# Altium schematic sheet SmartToy Main Board REV02 Schematic.SchDoc("SmartToy Main Board REV02 Schematic") (continued)
## Library data of the parts (continued)
R30 R31 = RES_SMD: RES SMD 100 OHM 5% 1/16W 0402; Manufacturer: Yageo; Manufacturer Part Number: RC0402FR-07100RL; Power Rating: 62.5mW; Resistance: 100R; Temperature Coefficient: 100ppm/°C; Voltage Rating: 50V; Case Code (Metric): 1005; Case/Package: 0402; Component Kind: Standard; ComponentLink1Description: https://datasheet.ciiva.com/7850/1018594-7850134.pdf?src-supplier=Element14; ComponentLink1URL: https://datasheet.ciiva.com/7850/1018594-7850134.pdf?src-supplier=Element14; ComponentLink2Description: https://datasheet.ciiva.com/7850/1018594-7850135.pdf?src-supplier=Element14; ComponentLink2URL: https://datasheet.ciiva.com/7850/1018594-7850135.pdf?src-supplier=Element14; ComponentLink3Description: https://datasheet.ciiva.com/2452/pyu-r-int-thick-7-2452617.pdf?src-supplier=Digi-Key; ComponentLink3URL: https://datasheet.ciiva.com/2452/pyu-r-int-thick-7-2452617.pdf?src-supplier=Digi-Key; ComponentLink4Description: https://datasheet.ciiva.com/2452/pyu-r-int-thick-7-2452618.pdf?src-supplier=Digi-Key; ComponentLink4URL: https://datasheet.ciiva.com/2452/pyu-r-int-thick-7-2452618.pdf?src-supplier=Digi-Key; ComponentLink5Description: https://datasheet.ciiva.com/2452/pyu-r-int-thick-7-2452619.pdf?src-supplier=Digi-Key; ComponentLink5URL: https://datasheet.ciiva.com/2452/pyu-r-int-thick-7-2452619.pdf?src-supplier=Digi-Key; ComponentLink6Description: https://datasheet.ciiva.com/16740/pyu-rc0402-51-rohs-l-6-r-16740924.pdf?src-supplier=Future+Electronics; ComponentLink6URL: https://datasheet.ciiva.com/16740/pyu-rc0402-51-rohs-l-6-r-16740924.pdf?src-supplier=Future+Electronics; ComponentLink7Description: https://datasheet.ciiva.com/12577/pyu-rc0402-51-rohs-l-6-r-12577725.pdf?src-supplier=Mouser; ComponentLink7URL: https://datasheet.ciiva.com/12577/pyu-rc0402-51-rohs-l-6-r-12577725.pdf?src-supplier=Mouser; ComponentLink8Description: https://datasheet.ciiva.com/46394/1018594-46394943.pdf?src-supplier=Element14; ComponentLink8URL: https://datasheet.ciiva.com/46394/1018594-46394943.pdf?src-supplier=Element14; ComponentLink9Description: https://datasheet.ciiva.com/7849/1784430-7849810.pdf?src-supplier=Element14; ComponentLink9URL: https://datasheet.ciiva.com/7849/1784430-7849810.pdf?src-supplier=Element14; ComponentLink10Description: https://datasheet.ciiva.com/11808/0900766b80c66297-11808765.pdf?src-supplier=RSComponents; ComponentLink10URL: https://datasheet.ciiva.com/11808/0900766b80c66297-11808765.pdf?src-supplier=RSComponents; ComponentLink11Description: https://datasheet.ciiva.com/12667/pyu-rc0402-51-rohs-l-6-r-12667957.pdf?src-supplier=Mouser; ComponentLink11URL: https://datasheet.ciiva.com/12667/pyu-rc0402-51-rohs-l-6-r-12667957.pdf?src-supplier=Mouser; ComponentLink12Description: https://datasheet.ciiva.com/16740/pyu-rc0402-51-rohs-l-6-r-16740566.pdf?src-supplier=Future+Electronics; ComponentLink12URL: https://datasheet.ciiva.com/16740/pyu-rc0402-51-rohs-l-6-r-16740566.pdf?src-supplier=Future+Electronics; ComponentLink13Description: https://datasheet.ciiva.com/15189/getdatasheetpartid-329466-15189335.pdf?src-supplier=Verical; ComponentLink13URL: https://datasheet.ciiva.com/15189/getdatasheetpartid-329466-15189335.pdf?src-supplier=Verical; ComponentLink14Description: https://datasheet.ciiva.com/22747/1018594-22747511.pdf?src-supplier=Element14; ComponentLink14URL: https://datasheet.ciiva.com/22747/1018594-22747511.pdf?src-supplier=Element14; ComponentLink15Description: https://datasheet.ciiva.com/28367/1018594-28367226.pdf?src-supplier=Element14; ComponentLink15URL: https://datasheet.ciiva.com/28367/1018594-28367226.pdf?src-supplier=Element14; Depth: 0.5mm; Height: 0.32mm; Lead Free: Lead Free; Length: 1mm; Library Name: CommonLib.SchLib; Max Operating Temperature: 155°C; Military Standard: Not; Min Operating Temperature: -55°C; Number of Pins: 2; Packaging: Tape and Reel; Pricing 1: 1 = 0.10000, 10 = 0.01400, 100 = 0.00580, 1000 = 0.00260, 2500 = 0.00226, 5000 = 0.00186 (USD); REACH SVHC: No SVHC; Resistor Type: Thick Film; RoHS: Yes; Stock 1: 18149776; Supplier 1: Digi-Key; Supplier Part Number 1: 311-100LRCT-ND; Termination: SMD/SMT; Voltage Rating (DC): 50V; Width: 0.5mm
R46 = RES_SMD: YAGEO (PHYCOMP) - RC0603FR-07330RL - RES, THICK FILM, 330R, 1%, 0.1W, 0603; Max Power Dissipation: 100mW; Mouting Technology: SMT; Temperature Coefficient: 100ppm/°C; Voltage Rating: 50V; Case Code (Metric): 1608; Case/Package: 0603; Category: Chip SMD Resistors; Component Kind: Standard; ComponentLink1Description: https://datasheet.ciiva.com/11819/0900766b80f96399-11819327.pdf?src-supplier=RSComponents; ComponentLink1URL: https://datasheet.ciiva.com/11819/0900766b80f96399-11819327.pdf?src-supplier=RSComponents; ComponentLink2Description: https://datasheet.ciiva.com/2454/pyu-r-int-thick-7-2454864.pdf?src-supplier=Digi-Key; ComponentLink2URL: https://datasheet.ciiva.com/2454/pyu-r-int-thick-7-2454864.pdf?src-supplier=Digi-Key; ComponentLink3Description: https://datasheet.ciiva.com/2454/pyu-r-int-thick-7-2454865.pdf?src-supplier=Digi-Key; ComponentLink3URL: https://datasheet.ciiva.com/2454/pyu-r-int-thick-7-2454865.pdf?src-supplier=Digi-Key; ComponentLink4Description: https://datasheet.ciiva.com/2454/pyu-r-int-thick-7-2454866.pdf?src-supplier=Digi-Key; ComponentLink4URL: https://datasheet.ciiva.com/2454/pyu-r-int-thick-7-2454866.pdf?src-supplier=Digi-Key; ComponentLink5Description: https://datasheet.ciiva.com/12555/rc0603-272842-12555334.pdf?src-supplier=Mouser; ComponentLink5URL: https://datasheet.ciiva.com/12555/rc0603-272842-12555334.pdf?src-supplier=Mouser; ComponentLink6Description: https://datasheet.ciiva.com/46440/1868787-46440904.pdf?src-supplier=Element14; ComponentLink6URL: https://datasheet.ciiva.com/46440/1868787-46440904.pdf?src-supplier=Element14; ComponentLink7Description: https://datasheet.ciiva.com/7746/443971-7746329.pdf?src-supplier=Element14; ComponentLink7URL: https://datasheet.ciiva.com/7746/443971-7746329.pdf?src-supplier=Element14; ComponentLink8Description: https://datasheet.ciiva.com/7746/443971-7746330.pdf?src-supplier=Element14; ComponentLink8URL: https://datasheet.ciiva.com/7746/443971-7746330.pdf?src-supplier=Element14; ComponentLink9Description: https://datasheet.ciiva.com/7746/1686128-7746331.pdf?src-supplier=Element14; ComponentLink9URL: https://datasheet.ciiva.com/7746/1686128-7746331.pdf?src-supplier=Element14; Depth: 0.8mm; Features: Moisture Resistant; Height: 0.45mm; Lead Free: Lead Free; Length: 1.6mm; Library Name: CommonLib.SchLib; Manufacturer 1: Yageo; Manufacturer Part Number 1: RC0603FR-07330RL; Max Operating Temperature: 155°C; Min Operating Temperature: -55°C; Number of Pins: 2; Number of Terminations: 2; Package Quantity: 1; Packaging: Tape and Reel; Pin: 2; Power Rating: 100mW; Pricing 1: 1=0.10000, 10=0.02400, 100=0.00970, 1000=0.00436, 2500=0.00378 (USD); REACH SVHC: No SVHC; Resistance: 330R; Resistor Type: Thick Film; RoHS: Yes; RoHS Compliant: Yes; Series: RC; Size Code: 0603; Stock 1: 1325793; Supplier 1: Digi-Key; Supplier Part Number 1: 311-330HRCT-ND; Technology: THICK FILM; Termination: SMD/SMT; Tolerance: 1%; Voltage Rating (DC): 50V; Width: 0.8mm
R55 = RES_SMD: RES SMD 499 OHM 5% 1/16W 0402; Manufacturer 1: Yageo; Manufacturer Part Number 1: RC0402FR-07499RL; Max Power Dissipation: 63mW; Mouting Technology: SMT; Power Rating: 62.5mW; Resistance: 499R; Temperature Coefficient: 100ppm/°C; Voltage Rating: 50V; Case Code (Metric): 1005; Case/Package: 0402; Category: Chip SMD Resistors; Component Kind: Standard; ComponentLink1Description: https://datasheet.ciiva.com/2453/pyu-r-int-thick-7-2453538.pdf?src-supplier=Digi-Key; ComponentLink1URL: https://datasheet.ciiva.com/2453/pyu-r-int-thick-7-2453538.pdf?src-supplier=Digi-Key; ComponentLink2Description: https://datasheet.ciiva.com/2453/pyu-r-int-thick-7-2453539.pdf?src-supplier=Digi-Key; ComponentLink2URL: https://datasheet.ciiva.com/2453/pyu-r-int-thick-7-2453539.pdf?src-supplier=Digi-Key; ComponentLink3Description: https://datasheet.ciiva.com/2453/pyu-r-int-thick-7-2453540.pdf?src-supplier=Digi-Key; ComponentLink3URL: https://datasheet.ciiva.com/2453/pyu-r-int-thick-7-2453540.pdf?src-supplier=Digi-Key; Construction: Rectangular; Depth: 0.5mm; Features: Moisture Resistant; Height: 0.016inch; Lead Free: Lead Free; Length: 1mm; Library Name: CommonLib.SchLib; Max Operating Temperature: 155°C; Military Standard: Not; Min Operating Temperature: -55°C; Number of Terminations: 2; Package Quantity: 1; Packaging: Tape and Reel; Pin: 2; Pricing 1: 1=0.10000, 10=0.01600, 100=0.00660, 1000=0.00299, 2500=0.00259, 5000=0.00214 (USD); REACH SVHC: No SVHC; Resistor Type: Thick Film; RoHS: Yes; RoHS Compliant: Yes; Series: RC; Size Code: 0402; Stock 1: 2245904; Supplier 1: Digi-Key; Supplier Part Number 1: 311-499LRCT-ND; Termination: SMD/SMT; Tolerance: 1%; Voltage Rating (DC): 50V; Width: 0.02inch
R56-R60 = RES_SMD: RES SMD 0 OHM JUMPER 1/16W 0402; Manufacturer 1: Yageo; Manufacturer Part Number 1: RC0402FR-070RL; Max Power Dissipation: 63mW; Mouting Technology: SMT; Power Rating: 62.5mW; Resistance: 0R; Temperature Coefficient: 200ppm/°C; Tolerance: 1%; Voltage Rating: 50V; Case Code (Imperial): 0402; Case Code (Metric): 1005; Case/Package: 0402; Category: Chip SMD Resistors; Component Kind: Standard; ComponentLink1Description: https://datasheet.ciiva.com/8896/pyu-rc0402-51-rohs-l-6-8896149.pdf?src-supplier=Avnet; ComponentLink1URL: https://datasheet.ciiva.com/8896/pyu-rc0402-51-rohs-l-6-8896149.pdf?src-supplier=Avnet; ComponentLink2Description: https://datasheet.ciiva.com/16790/pyu-rc0402-51-rohs-l-6-r-16790444.pdf?src-supplier=Future+Electronics; ComponentLink2URL: https://datasheet.ciiva.com/16790/pyu-rc0402-51-rohs-l-6-r-16790444.pdf?src-supplier=Future+Electronics; ComponentLink3Description: https://datasheet.ciiva.com/28419/pyu-rc0402-51-rohs-l-6-28419661.pdf?src-supplier=Avnet+Europe; ComponentLink3URL: https://datasheet.ciiva.com/28419/pyu-rc0402-51-rohs-l-6-28419661.pdf?src-supplier=Avnet+Europe; ComponentLink4Description: https://datasheet.ciiva.com/16869/pyu-rc0402-51-rohs-l-6-16869518.pdf?src-supplier=Avnet+Europe; ComponentLink4URL: https://datasheet.ciiva.com/16869/pyu-rc0402-51-rohs-l-6-16869518.pdf?src-supplier=Avnet+Europe; Contact Plating: Tin,Matte; Features: Moisture Resistant; Height: 0.35mm; Lead Free: Lead Free; Length: 1mm; Library Name: CommonLib.SchLib; Max Operating Temperature: 155°C; Min Operating Temperature: -55°C; Mount: Surface Mount; Number of Terminations: 2; Packaging: Tape and Reel; Pin: 2; Pricing 1: 1=0.10000, 10=0.01000, 50=0.01000, 100=0.00400, 1000=0.00300, 10000=0.00200 (USD); Radiation Hardening: No; Resistor Type: Thick Film; RoHS: Yes; RoHS Compliant: Yes; Series: RC; Size Code: 0402; Stock 1: 204375; Supplier 1: Mouser; Supplier Part Number 1: 603-RC0402FR-070RL; Termination: SMD/SMT; Width: 0.5mm
U1 = MIC2875-AYMT-T5: IC REG BOOST ADJ 3.8A 8TDFN; Manufacturer 1: Microchip; Category: Voltage Regulators - Switching; Component Kind: Standard; ComponentLink1Description: http://www.microchip.com/mymicrochip/filehandler.aspx?ddocname=en580778&src-supplier=Digi-Key; ComponentLink1URL: http://www.microchip.com/mymicrochip/filehandler.aspx?ddocname=en580778&src-supplier=Digi-Key; Manufacturer Part Number 1: MIC2875-AYMT-T5; Pricing 1: 1=1.27000, 25=1.05000, 100=0.96000 (USD); RoHS: No; Stock 1: 322; Supplier 1: Digi-Key; Supplier Part Number 1: 576-4863-1-ND; Library Name: SmartToy.SchLib
U2 = W25N01GVZEIG TR: IC FLASH 1G SPI 104MHZ 8WSON; Manufacturer 1: Winbond; Interface: SPI,Serial; Memory Type: FLASH,NAND; Category: Memory; Component Kind: Standard; ComponentLink1Description: http://www.winbond.com/resource-files/w25n01gv%20revl%20050918%20unsecured.pdf?src-supplier=Digi-Key; ComponentLink1URL: http://www.winbond.com/resource-files/w25n01gv%20revl%20050918%20unsecured.pdf?src-supplier=Digi-Key; Frequency: 104MHz; Manufacturer Part Number 1: W25N01GVZEIG TR; Max Operating Temperature: 85°C; Min Operating Temperature: -40°C; Packaging: Tape and Reel; Pricing 1: 1=3.21000, 10=2.88200, 25=2.83760, 50=2.83000, 100=2.52870, 250=2.44564, 500=2.43672, 1000=2.26904 (USD); RoHS: Yes; RoHS Compliant: Yes; Stock 1: 33713; Supplier 1: Digi-Key; Supplier Part Number 1: W25N01GVZEIGCT-ND; Library Name: SmartToy.SchLib
U3 = ESP32-S2: RF System on a Chip - SoC SMD Wi-Fi IC, ESP32-S2, singal-core MCU, QFN 56-pin, 7*7 mm; Manufacturer 1: Espressif Systems; Component Kind: Standard; Manufacturer Part Number 1: ESP32-S2; Library Name: SmartToy.SchLib
U4 = AT42QT1110-MUR: Capacitive Touch Screen 11 Key 32-Pin VQFN EP T/R; Manufacturer 1: Microchip; Component Kind: Standard; ComponentLink1Description: http://www.microchip.com/mymicrochip/filehandler.aspx?ddocname=en590256&src-supplier=Digi-Key; ComponentLink1URL: http://www.microchip.com/mymicrochip/filehandler.aspx?ddocname=en590256&src-supplier=Digi-Key; Manufacturer Part Number 1: AT42QT1110-MUR; Pricing 1: 1=1.16000, 25=1.06000, 100=0.96200 (USD); RoHS: No; Stock 1: 4052; Supplier 1: Digi-Key; Supplier Part Number 1: AT42QT1110-MURCT-ND; Library Name: SmartToy.SchLib
U5 = W25N01GVZEIG TR: IC FLASH 128MBIT SPI/QUAD 8SOIC; Manufacturer 1: Winbond; Frequency: 133MHz; Memory Size: 128Mb; Memory Type: Non-Volatile,FLASH; Case/Package: SOIC; Category: Memory; Component Kind: Standard; ComponentLink1Description: http://www.winbond.com/resource-files/w25n01gv%20revl%20050918%20unsecured.pdf?src-supplier=Digi-Key; ComponentLink1URL: http://www.winbond.com/resource-files/w25n01gv%20revl%20050918%20unsecured.pdf?src-supplier=Digi-Key; ComponentLink2Description: http://www.winbond.com/resource-files/w25q128jv_dtr%20revc%2003272018%20plus.pdf?src-supplier=Digi-Key; ComponentLink2URL: http://www.winbond.com/resource-files/w25q128jv_dtr%20revc%2003272018%20plus.pdf?src-supplier=Digi-Key; Manufacturer Part Number 1: W25Q128JVSIM; Max Operating Temperature: 85°C; Min Operating Temperature: -40°C; Mount: Surface Mount; Packaging: Rail/Tube; Pricing 1: 1=1.62000, 10=1.48100, 25=1.44080, 50=1.43360, 100=1.28140, 250=1.27772, 500=1.25844, 1000=1.20501, 5000=1.12190 (USD); RoHS: Yes; RoHS Compliant: Yes; Stock 1: 4617; Supplier 1: Digi-Key; Supplier Part Number 1: W25Q128JVSIM-ND; Library Name: SmartToy.SchLib
U6 = AntenaIPX: CONN UMCC JACK STR 50OHM SMD; Manufacturer: TE Connectivity AMP; Body Material: Polymer,Liquid Crystal Polymer; Body Plating: Gold; Connector System: Cable-to-Board; Dielectric Material: Polymer; Frequency: 6GHz; Gender: Female; Impedance: 50R; Number of Positions: 1; Sealable: No (Without); Case/Package: SMD/SMT; Component Kind: Standard; ComponentLink1Description: https://datasheet.ciiva.com/16312/eng-cd-1909763-b-16312469.pdf?src-supplier=Future+Electronics; ComponentLink1URL: https://datasheet.ciiva.com/16312/eng-cd-1909763-b-16312469.pdf?src-supplier=Future+Electronics; ComponentLink2Description: https://datasheet.ciiva.com/16469/eng-cd-1909763-b-16469221.pdf?src-supplier=Avnet+Asia; ComponentLink2URL: https://datasheet.ciiva.com/16469/eng-cd-1909763-b-16469221.pdf?src-supplier=Avnet+Asia; ComponentLink3Description: https://datasheet.ciiva.com/9423/eng-cd-1909763-b-9423785.pdf?src-supplier=Avnet; ComponentLink3URL: https://datasheet.ciiva.com/9423/eng-cd-1909763-b-9423785.pdf?src-supplier=Avnet; ComponentLink4Description: https://datasheet.ciiva.com/29191/eng-cd-1909763-b1-basefilename-29191146.pdf?src-supplier=Digi-Key; ComponentLink4URL: https://datasheet.ciiva.com/29191/eng-cd-1909763-b1-basefilename-29191146.pdf?src-supplier=Digi-Key; ComponentLink5Description: https://datasheet.ciiva.com/29191/eng-cd-1909763-b1-basefilename-29191148.pdf?src-supplier=Digi-Key; ComponentLink5URL: https://datasheet.ciiva.com/29191/eng-cd-1909763-b1-basefilename-29191148.pdf?src-supplier=Digi-Key; Connector Type: Jack; Contact Material: Copper Alloy; Contact Plating: Gold; ELV: Compliant; Fastening Type: Push,Pull; Housing Color: Beige; Insulation Material: Thermoplastic; Lead Free: Lead Free; Length: 3mm; Library Name: CommonLib.SchLib; Manufacturer Part Number: 1909763-1; Max Frequency: 6GHz; Max Operating Temperature: 194°C; Min Operating Temperature: -40°C; Mount: Panel; Orientation: Straight; Package Quantity: 1; Packaging: Tape and Reel; Pricing 1: 1 = 0.46000, 10 = 0.42900, 25 = 0.38600, 50 = 0.34300, 100 = 0.32860, 250 = 0.30008, 500 = 0.28578, 1000 = 0.23577, 2500 = 0.21434 (USD); Radiation Hardening: No; RoHS: Yes; Stock 1: 175154; Supplier 1: Digi-Key; Supplier Part Number 1: A118077CT-ND; Termination: SMD/SMT
X1 = FA-128 32.0000MF10Z-AJ3: CRYSTAL 40.0000MHZ 8PF SMD; Manufacturer 1: Abracon; Manufacturer Part Number 1: ABM11W-40.0000MHZ-8-B1U-T3; Continuous Drain Current (ID): 22A; Drain to Source Voltage (Vdss): 60V; ESR (Equivalent Series Resistance): 60R; Frequency Stability: 10ppm; Input Capacitance: 2.2nF; Max Power Dissipation: 79W; Rds On Max: 4mR; Case/Package: DFN; Component Kind: Standard; ComponentLink1Description: https://abracon.com/Resonators/ABM11W.pdf?src-supplier=Digi-Key; ComponentLink1URL: https://abracon.com/Resonators/ABM11W.pdf?src-supplier=Digi-Key; Frequency: 40MHz; Frequency Tolerance: 10ppm; Load Capacitance: 8pF; Max Operating Temperature: 175°C; Min Operating Temperature: -55°C; Mount: Surface Mount; Packaging: Cut Tape; Pricing 1: 1=0.78000, 10=0.69000, 50=0.64500, 100=0.57000, 500=0.54000 (USD); RoHS: Yes; Stock 1: 770; Supplier 1: Digi-Key; Supplier Part Number 1: 535-13918-1-ND; Library Name: Vband.SchLib
